# Altium schematic sheet Top.SchDoc(Top)
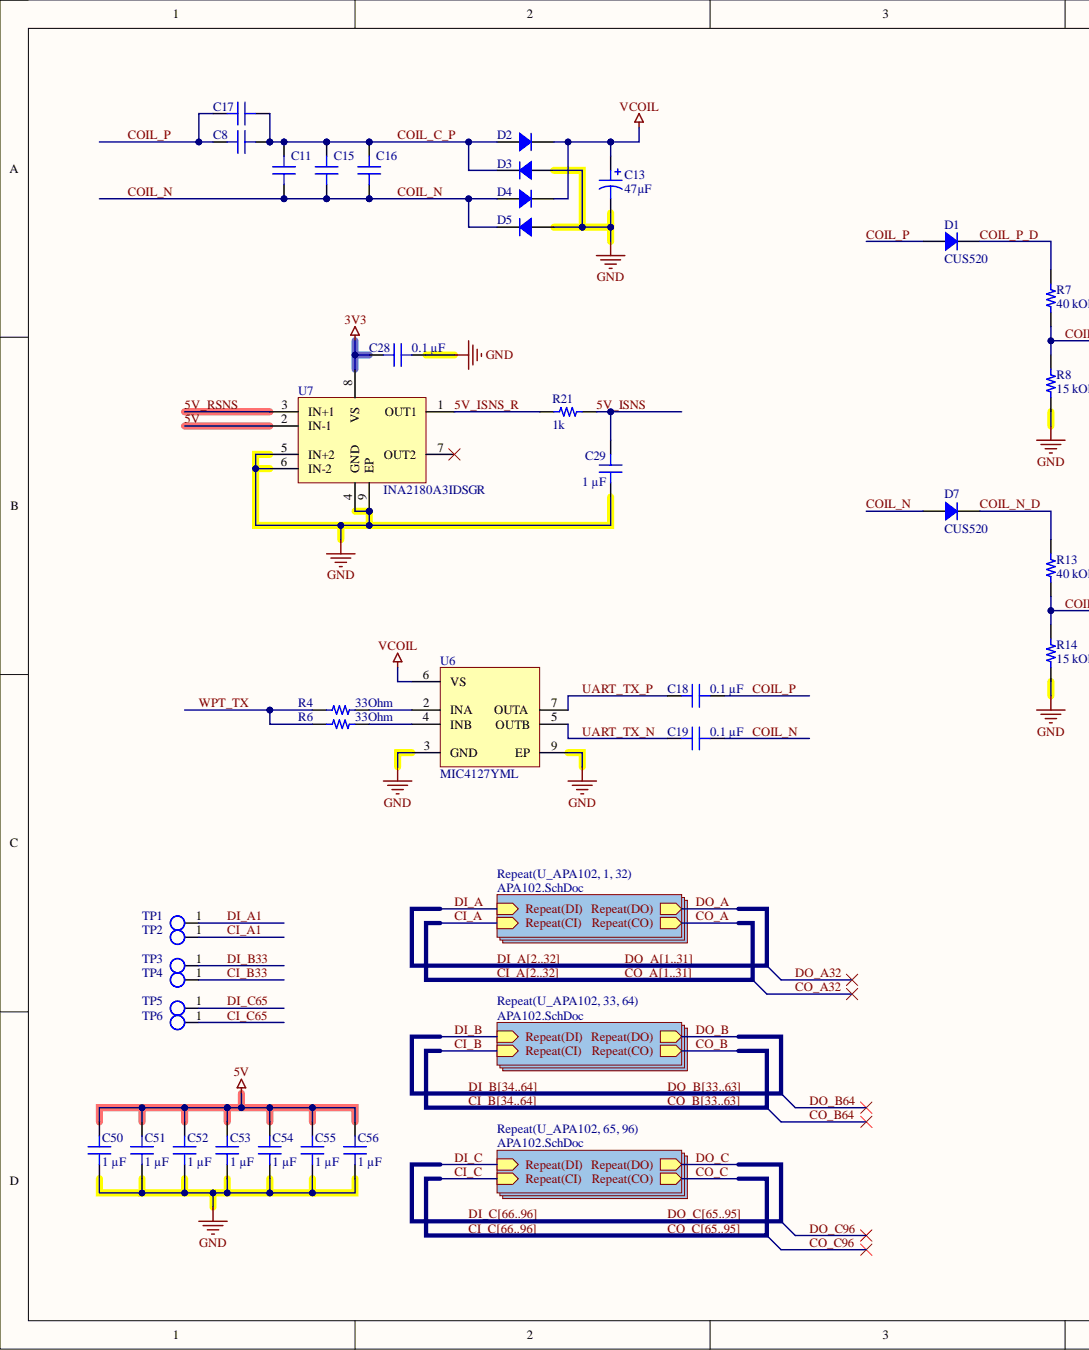
[diagram p0: Top.SchDoc, left]
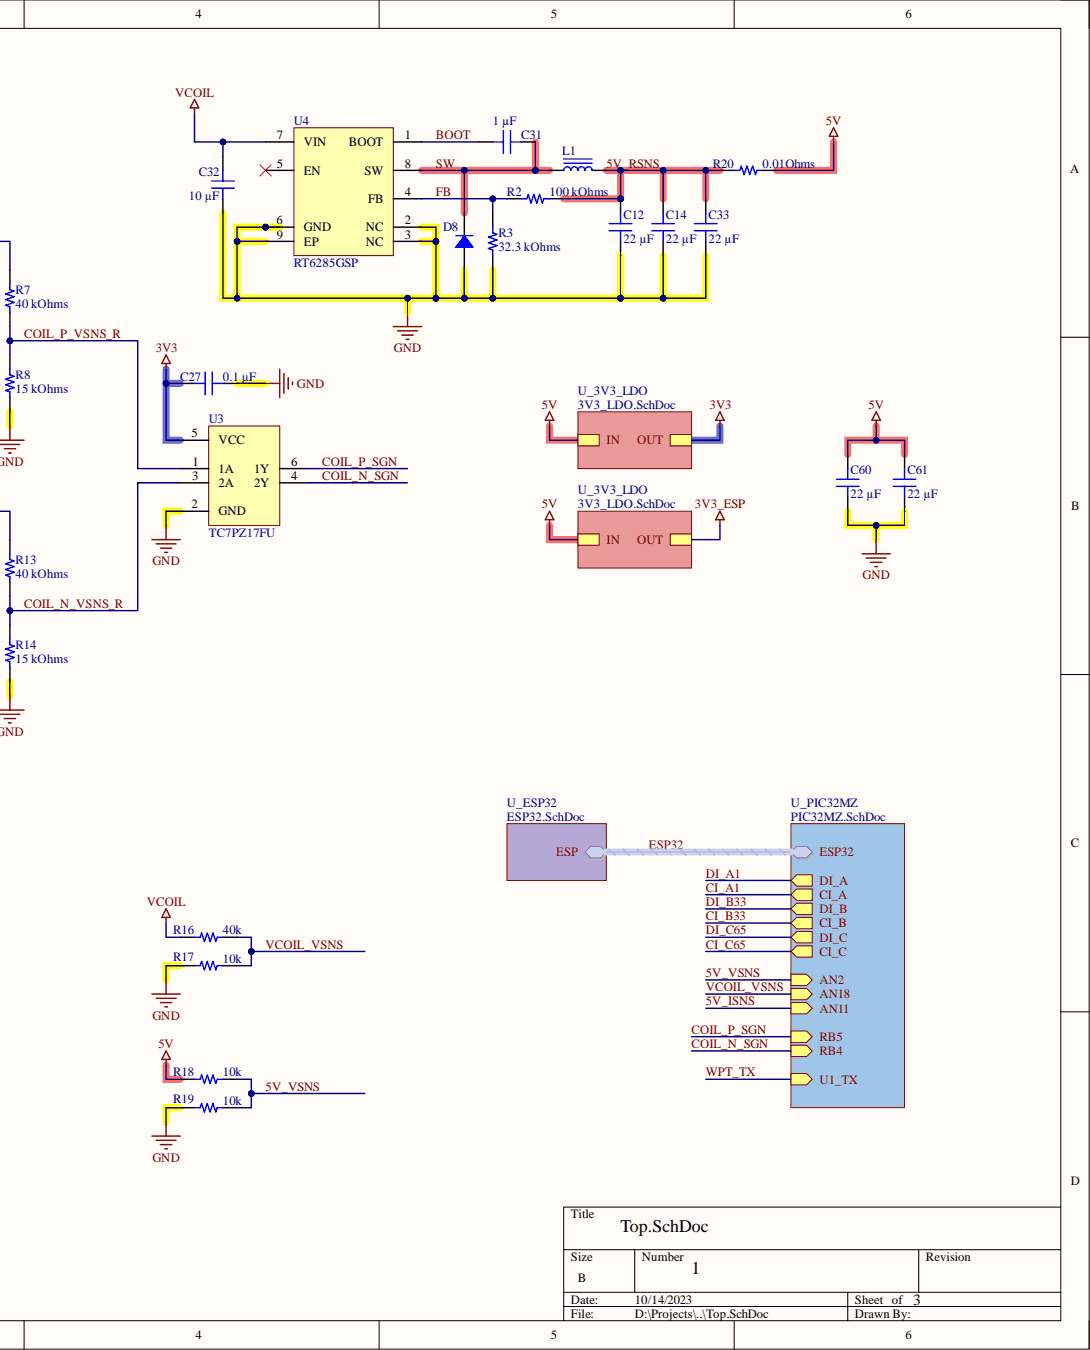
[diagram p1: Top.SchDoc, right]

## Parts
C8  =Value  [CAP 1206_3216 - 0.8MM]
C11  =Value  [CAP 1206_3216 - 0.8MM]
C12  22 µF  [CAP 0603_1608]
C13  47µF  [CAP ALSMD 6.6MM H6.1MM]
C14  22 µF  [CAP 0603_1608]
C15  =Value  [CAP 1206_3216 - 0.8MM]
C16  =Value  [CAP 1206_3216 - 0.8MM]
C17  =Value  [CAP 1206_3216 - 0.8MM]
C18  0.1 µF  [CAP 0402_1005]
C19  0.1 µF  [CAP 0402_1005]
C27  0.1 µF  [CAP 0402_1005]
C28  0.1 µF  [CAP 0402_1005]
C29  1 µF  [CAP 0402_1005]
C31  1 µF  [CAP 0402_1005]
C32  10 µF  [CAP 1210_3225 - 1MM]
C33  22 µF  [CAP 0603_1608]
C50  1 µF  [CAP 0402_1005]
C51  1 µF  [CAP 0402_1005]
C52  1 µF  [CAP 0402_1005]
C53  1 µF  [CAP 0402_1005]
C54  1 µF  [CAP 0402_1005]
C55  1 µF  [CAP 0402_1005]
C56  1 µF  [CAP 0402_1005]
C60  22 µF  [CAP 0603_1608]
C61  22 µF  [CAP 0603_1608]
D1  CUS520  [SOD323]
D2  STPS340UY  [DO214AA SMB]  (value not drawn)
D3  STPS340UY  [DO214AA SMB]  (value not drawn)
D4  STPS340UY  [DO214AA SMB]  (value not drawn)
D5  STPS340UY  [DO214AA SMB]  (value not drawn)
D7  CUS520  [SOD323]
D8  STPS340UY  [DO214AA SMB]  (value not drawn)
L1  MPL-AL5050-5R6  [MPL-AL5050-5R6]  (value not drawn)
R2  100 kOhms  [RES 0402_1005]
R3  32.3 kOhms  [RES 0402_1005]
R4  33Ohm  [RES 0402_1005]
R6  33Ohm  [RES 0402_1005]
R7  40 kOhms  [RES 0402_1005]
R8  15 kOhms  [RES 0402_1005]
R13  40 kOhms  [RES 0402_1005]
R14  15 kOhms  [RES 0402_1005]
R16  40k  [RES 0402_1005]
R17  10k  [RES 0402_1005]
R18  10k  [RES 0402_1005]
R19  10k  [RES 0402_1005]
R20  0.01Ohms  [RES 0805_2012]
R21  1k  [RES 0402_1005]
TP1  0.8mm  [TP  0.8mm]  (value not drawn)
TP2  0.8mm  [TP  0.8mm]  (value not drawn)
TP3  0.8mm  [TP  0.8mm]  (value not drawn)
TP4  0.8mm  [TP  0.8mm]  (value not drawn)
TP5  0.8mm  [TP  0.8mm]  (value not drawn)
TP6  0.8mm  [TP  0.8mm]  (value not drawn)
U3  TC7PZ17FU  [SOT-363]
U4  RT6285GSP  [8-SOIC Richtek]
U6  MIC4127YML  [VDFN-8 3x3x0.9]
U7  INA2180A3IDSGR  [SON50P200X200X80-9N]

## Nets
3V3: C27.2 C28.2 U3.5(VCC) U7.8(VS)
GND: C12.1 C13.2 C14.1 C27.1 C28.1 C29.2 C32.1 C33.1 C50.1 C51.1 C52.1 C53.1 C54.1 C55.1 C56.1 C60.1 C61.1 D3.1 D5.1 D8.1 R3.1 R8.1 R14.1 R17.2 R19.2 U3.2(GND) U4.2(NC) U4.3(NC) U4.6(GND) U4.9(EP) U6.3(GND) U6.9(EP) U7.4(GND) U7.5(IN+2) U7.6(IN-2) U7.9(EP)
VCOIL: C13.1 C32.2 D2.2 D4.2 R16.2 U4.7(VIN) U6.6(VS)
5V: C50.2 C51.2 C52.2 C53.2 C54.2 C55.2 C56.2 C60.2 C61.2 R18.2 R20.2 U7.2(IN-1)
5V_ISNS: C29.1 R21.1
5V_ISNS_R: R21.2 U7.1(OUT1)
5V_RSNS: C12.2 C14.2 C33.2 L1.2 R2.2 R20.1 U7.3(IN+1)
5V_VSNS: R18.1 R19.1
BOOT: C31.2 U4.1(BOOT)
CI_A1: TP2.1
CI_B33: TP4.1
CI_C65: TP6.1
COIL_C_P: C8.1 C11.2 C15.2 C16.2 C17.1 D2.1 D3.2
COIL_N: C11.1 C15.1 C16.1 C19.2 D4.1 D5.2 D7.1
COIL_N_D: D7.2 R13.2
COIL_N_SGN: U3.4(2Y)
COIL_N_VSNS_R: R13.1 R14.2 U3.3(2A)
COIL_P: C8.2 C17.2 C18.2 D1.1
COIL_P_D: D1.2 R7.2
COIL_P_SGN: U3.6(1Y)
COIL_P_VSNS_R: R7.1 R8.2 U3.1(1A)
DI_A1: TP1.1
DI_B33: TP3.1
DI_C65: TP5.1
FB: R2.1 R3.2 U4.4(FB)
SW: C31.1 D8.2 L1.1 U4.8(SW)
UART_TX_N: C19.1 U6.5(OUTB)
UART_TX_P: C18.1 U6.7(OUTA)
VCOIL_VSNS: R16.1 R17.1
WPT_TX: R4.1 R6.1
NetR4_2: R4.2 U6.2(INA)
NetR6_2: R6.2 U6.4(INB)
NetU4_5: U4.5(EN)
NetU7_7: U7.7(OUT2)
3V3_ESP:
CI_A2:  [also labelled CI_A]
CI_A3:  [also labelled CI_A]
CI_A4:  [also labelled CI_A]
CI_A5:  [also labelled CI_A]
CI_A6:  [also labelled CI_A]
CI_A7:  [also labelled CI_A]
CI_A8:  [also labelled CI_A]
CI_A9:  [also labelled CI_A]
CI_A10:  [also labelled CI_A]
CI_A11:  [also labelled CI_A]
CI_A12:  [also labelled CI_A]
CI_A13:  [also labelled CI_A]
CI_A14:  [also labelled CI_A]
CI_A15:  [also labelled CI_A]
CI_A16:  [also labelled CI_A]
CI_A17:  [also labelled CI_A]
CI_A18:  [also labelled CI_A]
CI_A19:  [also labelled CI_A]
CI_A20:  [also labelled CI_A]
CI_A21:  [also labelled CI_A]
CI_A22:  [also labelled CI_A]
CI_A23:  [also labelled CI_A]
CI_A24:  [also labelled CI_A]
CI_A25:  [also labelled CI_A]
CI_A26:  [also labelled CI_A]
CI_A27:  [also labelled CI_A]
CI_A28:  [also labelled CI_A]
CI_A29:  [also labelled CI_A]
CI_A30:  [also labelled CI_A]
CI_A31:  [also labelled CI_A]
CI_A32:  [also labelled CI_A]
CI_B34:  [also labelled CI_B]
CI_B35:  [also labelled CI_B]
CI_B36:  [also labelled CI_B]
CI_B37:  [also labelled CI_B]
CI_B38:  [also labelled CI_B]
CI_B39:  [also labelled CI_B]
CI_B40:  [also labelled CI_B]
CI_B41:  [also labelled CI_B]
CI_B42:  [also labelled CI_B]
CI_B43:  [also labelled CI_B]
CI_B44:  [also labelled CI_B]
CI_B45:  [also labelled CI_B]
CI_B46:  [also labelled CI_B]
CI_B47:  [also labelled CI_B]
CI_B48:  [also labelled CI_B]
CI_B49:  [also labelled CI_B]
CI_B50:  [also labelled CI_B]
CI_B51:  [also labelled CI_B]
CI_B52:  [also labelled CI_B]
CI_B53:  [also labelled CI_B]
CI_B54:  [also labelled CI_B]
CI_B55:  [also labelled CI_B]
CI_B56:  [also labelled CI_B]
CI_B57:  [also labelled CI_B]
CI_B58:  [also labelled CI_B]
CI_B59:  [also labelled CI_B]
CI_B60:  [also labelled CI_B]
CI_B61:  [also labelled CI_B]
CI_B62:  [also labelled CI_B]
CI_B63:  [also labelled CI_B]
CI_B64:  [also labelled CI_B]
CI_C66:  [also labelled CI_C]
CI_C67:  [also labelled CI_C]
CI_C68:  [also labelled CI_C]
CI_C69:  [also labelled CI_C]
CI_C70:  [also labelled CI_C]
CI_C71:  [also labelled CI_C]
CI_C72:  [also labelled CI_C]
CI_C73:  [also labelled CI_C]
CI_C74:  [also labelled CI_C]
CI_C75:  [also labelled CI_C]
CI_C76:  [also labelled CI_C]
CI_C77:  [also labelled CI_C]
CI_C78:  [also labelled CI_C]
CI_C79:  [also labelled CI_C]
CI_C80:  [also labelled CI_C]
CI_C81:  [also labelled CI_C]
CI_C82:  [also labelled CI_C]
CI_C83:  [also labelled CI_C]
CI_C84:  [also labelled CI_C]
CI_C85:  [also labelled CI_C]
CI_C86:  [also labelled CI_C]
CI_C87:  [also labelled CI_C]
CI_C88:  [also labelled CI_C]
CI_C89:  [also labelled CI_C]
CI_C90:  [also labelled CI_C]
CI_C91:  [also labelled CI_C]
CI_C92:  [also labelled CI_C]
CI_C93:  [also labelled CI_C]
CI_C94:  [also labelled CI_C]
CI_C95:  [also labelled CI_C]
CI_C96:  [also labelled CI_C]
CO_A32:
CO_B64:
CO_C96:
DI_A2:  [also labelled DI_A]
DI_A3:  [also labelled DI_A]
DI_A4:  [also labelled DI_A]
DI_A5:  [also labelled DI_A]
DI_A6:  [also labelled DI_A]
DI_A7:  [also labelled DI_A]
DI_A8:  [also labelled DI_A]
DI_A9:  [also labelled DI_A]
DI_A10:  [also labelled DI_A]
DI_A11:  [also labelled DI_A]
DI_A12:  [also labelled DI_A]
DI_A13:  [also labelled DI_A]
DI_A14:  [also labelled DI_A]
DI_A15:  [also labelled DI_A]
DI_A16:  [also labelled DI_A]
DI_A17:  [also labelled DI_A]
DI_A18:  [also labelled DI_A]
DI_A19:  [also labelled DI_A]
DI_A20:  [also labelled DI_A]
DI_A21:  [also labelled DI_A]
DI_A22:  [also labelled DI_A]
DI_A23:  [also labelled DI_A]
DI_A24:  [also labelled DI_A]
DI_A25:  [also labelled DI_A]
DI_A26:  [also labelled DI_A]
DI_A27:  [also labelled DI_A]
DI_A28:  [also labelled DI_A]
DI_A29:  [also labelled DI_A]
DI_A30:  [also labelled DI_A]
DI_A31:  [also labelled DI_A]
DI_A32:  [also labelled DI_A]
DI_B34:  [also labelled DI_B]
DI_B35:  [also labelled DI_B]
DI_B36:  [also labelled DI_B]
DI_B37:  [also labelled DI_B]
DI_B38:  [also labelled DI_B]
DI_B39:  [also labelled DI_B]
DI_B40:  [also labelled DI_B]
DI_B41:  [also labelled DI_B]
DI_B42:  [also labelled DI_B]
DI_B43:  [also labelled DI_B]
DI_B44:  [also labelled DI_B]
DI_B45:  [also labelled DI_B]
DI_B46:  [also labelled DI_B]
DI_B47:  [also labelled DI_B]
DI_B48:  [also labelled DI_B]
DI_B49:  [also labelled DI_B]
DI_B50:  [also labelled DI_B]
DI_B51:  [also labelled DI_B]
DI_B52:  [also labelled DI_B]
DI_B53:  [also labelled DI_B]
DI_B54:  [also labelled DI_B]
DI_B55:  [also labelled DI_B]
DI_B56:  [also labelled DI_B]
DI_B57:  [also labelled DI_B]
DI_B58:  [also labelled DI_B]
DI_B59:  [also labelled DI_B]
DI_B60:  [also labelled DI_B]
DI_B61:  [also labelled DI_B]
DI_B62:  [also labelled DI_B]
DI_B63:  [also labelled DI_B]
DI_B64:  [also labelled DI_B]
DI_C66:  [also labelled DI_C]
DI_C67:  [also labelled DI_C]
DI_C68:  [also labelled DI_C]
DI_C69:  [also labelled DI_C]
DI_C70:  [also labelled DI_C]
DI_C71:  [also labelled DI_C]
DI_C72:  [also labelled DI_C]
DI_C73:  [also labelled DI_C]
DI_C74:  [also labelled DI_C]
DI_C75:  [also labelled DI_C]
DI_C76:  [also labelled DI_C]
DI_C77:  [also labelled DI_C]
DI_C78:  [also labelled DI_C]
DI_C79:  [also labelled DI_C]
DI_C80:  [also labelled DI_C]
DI_C81:  [also labelled DI_C]
DI_C82:  [also labelled DI_C]
DI_C83:  [also labelled DI_C]
DI_C84:  [also labelled DI_C]
DI_C85:  [also labelled DI_C]
DI_C86:  [also labelled DI_C]
DI_C87:  [also labelled DI_C]
DI_C88:  [also labelled DI_C]
DI_C89:  [also labelled DI_C]
DI_C90:  [also labelled DI_C]
DI_C91:  [also labelled DI_C]
DI_C92:  [also labelled DI_C]
DI_C93:  [also labelled DI_C]
DI_C94:  [also labelled DI_C]
DI_C95:  [also labelled DI_C]
DI_C96:  [also labelled DI_C]
DO_A32:
DO_B64:
DO_C96:
ESP32.~{CS}:
ESP32.SCK:
ESP32.SDI:
ESP32.SDO:

## Library data of the parts
C8 C11 C15-C17 = C1206C223KBRAC7800: CAP CER 0.022UF 630V X7R 1206; manufacturer: KEMET; Capacitance: 0.022 µF; Database Table Name: Capacitors - Ceramic - 1206; Tolerance: ±10%; Applications: General Purpose; Component Kind: Standard; componentlink1description: Datasheet; componentlink1url: https://api.kemet.com/component-edge/download/datasheet/C1206C223KBRAC7800.pdf; componentlink2description: DigiKey Link; componentlink2url: https://www.digikey.com/en/products/detail/kemet/C1206C223KBRAC7800/7382212; Device Package: 1206 (3216 Metric); Features: Low ESL, High Voltage; lastupdated: 9/29/2023 7:15:32 PM; Library Name: Celestial Altium Library - altium_library.DbLib; Lifecycle Status: Active; Minimum Order: 1; Mounting Type: Surface Mount, MLCC; Operating Temperature: -55°C ~ 125°C; Package / Case: 1206 (3216 Metric); packaging: TapeAndReel; Part Number: C1206C223KBRAC7800; Part Status: Active; partid: 40769; price: 0.05; series: SMD Comm X7R HV; Size / Dimension: 0.126" L x 0.063" W (3.20mm x 1.60mm); Supplier 1: DigiKey; Supplier Part Number 1: 399-15097-1-ND; Temperature Coefficient: X7R; Thickness (Max): 0.075" (1.90mm); Voltage - Rated: 630V
C12 C14 C33 C60 C61 = 0603ZD226MAT2A: CAP CER 22UF 10V X5R 0603; manufacturer: KYOCERA AVX; Database Table Name: Capacitors - Ceramic - 0603; Tolerance: ±20%; Applications: General Purpose; Capacitance: 22 µF; Component Kind: Standard; componentlink2description: DigiKey Link; componentlink2url: https://www.digikey.com/en/products/detail/kyocera-avx/0603ZD226MAT2A/11268185; Device Package: 0603 (1608 Metric); lastupdated: 9/24/2023 7:08:40 PM; Library Name: Celestial Altium Library - altium_library.DbLib; Lifecycle Status: Active; Minimum Order: 1; Mounting Type: Surface Mount, MLCC; Operating Temperature: -55°C ~ 85°C; Package / Case: 0603 (1608 Metric); packaging: TapeAndReel; Part Number: 0603ZD226MAT2A; Part Status: Active; partid: 35789; price: 0.13; series: -; Size / Dimension: 0.063" L x 0.032" W (1.60mm x 0.81mm); Supplier 1: DigiKey; Supplier Part Number 1: 478-0603ZD226MAT2ACT-ND; Temperature Coefficient: X5R; Thickness (Max): 0.035" (0.90mm); Value: 22 µF; Voltage - Rated: 10V
C13 = EMHL160ARA470MF61G: ALUMINUM CAPACITOR; Manufacturer: United Chemi-Con; Database Table Name: Capacitors - Aluminum - Electrolytic SMT; ESR (Equivalent Series Resistance): 1.2Ohm @ 100kHz; Lifetime @ Temp.: 2000 Hrs @ 125°C; Polarization: Polar; Ripple Current @ High Frequency: 110mA @ 100kHz; Ripple Current @ Low Frequency: 102.3mA @ 120Hz; Surface Mount Land Size: 0.260" L x 0.260" W (6.60mm x 6.60mm); Tolerance: ±20%; Voltage - Rated: 16V; Applications: Automotive; Capacitance: 47µF; Component Kind: Standard; ComponentLink1Description: Datasheet; ComponentLink1URL: http://www.chemi-con.co.jp/cgi-bin/CAT_DB/SEARCH/cat_db_al.cgi?e=e&j=p&pdfname=mhl; ComponentLink2Description: DigiKey Link; ComponentLink2URL: http://digikey.com/product-detail/en/united-chemi-con/EMHL160ARA470MF61G/565-EMHL160ARA470MF61GCT-ND/10487181; Device Package: Radial, Can - SMD; Height - Seated (Max): 0.240" (6.10mm); LastUpdated: 9/2/2020 1:25:27 AM; Library Name: Celestial Altium Library - altium_library.DbLib; Lifecycle Status: Active; Minimum Order: 1; Mounting Type: Surface Mount; Operating Temperature: -40°C ~ 125°C; Package / Case: Radial, Can - SMD; Packaging: TapeAndReel; Part Number: EMHL160ARA470MF61G; Part Status: Active; PartId: 8133; Price: 0.15; Ratings: AEC-Q200; Series: AIchip™- MHL; Size / Dimension: 0.248" Dia (6.30mm); Supplier 1: DigiKey; Supplier Part Number 1: 565-EMHL160ARA470MF61GCT-ND; Value: 47µF
C18 C19 C27 C28 = C1005X5R1E104K050BC: CAP CER 0.1UF 25V X5R 0402; manufacturer: TDK Corporation; Database Table Name: Capacitors - Ceramic - 0402; Tolerance: ±10%; Applications: General Purpose; Capacitance: 0.1 µF; Component Kind: Standard; componentlink1description: Datasheet; componentlink1url: https://product.tdk.com/info/en/catalog/datasheets/mlcc_commercial_general_en.pdf?ref_disty=digikey; componentlink2description: DigiKey Link; componentlink2url: https://www.digikey.com/en/products/detail/tdk-corporation/C1005X5R1E104K050BC/2093232; Device Package: 0402 (1005 Metric); Features: Low ESL; lastupdated: 9/24/2023 7:03:59 PM; Library Name: Celestial Altium Library - altium_library.DbLib; Lifecycle Status: Active; Minimum Order: 1; Mounting Type: Surface Mount, MLCC; Operating Temperature: -55°C ~ 85°C; Package / Case: 0402 (1005 Metric); packaging: TapeAndReel; Part Number: C1005X5R1E104K050BC; Part Status: Active; partid: 34396; price: 0.01; series: C; Size / Dimension: 0.039" L x 0.020" W (1.00mm x 0.50mm); Supplier 1: DigiKey; Supplier Part Number 1: 445-4964-1-ND; Temperature Coefficient: X5R; Thickness (Max): 0.022" (0.55mm); Value: 0.1 µF; Voltage - Rated: 25V
C29 C31 = C1005X5R1E104K050BC: CAP CER 0.1UF 25V X5R 0402; manufacturer: TDK Corporation; Capacitance: 0.1 µF; Database Table Name: Capacitors - Ceramic - 0402; Tolerance: ±10%; Applications: General Purpose; Component Kind: Standard; componentlink1description: Datasheet; componentlink1url: https://product.tdk.com/info/en/catalog/datasheets/mlcc_commercial_general_en.pdf?ref_disty=digikey; componentlink2description: DigiKey Link; componentlink2url: https://www.digikey.com/en/products/detail/tdk-corporation/C1005X5R1E104K050BC/2093232; Device Package: 0402 (1005 Metric); Features: Low ESL; lastupdated: 9/24/2023 7:03:59 PM; Library Name: Celestial Altium Library - altium_library.DbLib; Lifecycle Status: Active; Minimum Order: 1; Mounting Type: Surface Mount, MLCC; Operating Temperature: -55°C ~ 85°C; Package / Case: 0402 (1005 Metric); packaging: TapeAndReel; Part Number: C1005X5R1E104K050BC; Part Status: Active; partid: 34396; price: 0.01; series: C; Size / Dimension: 0.039" L x 0.020" W (1.00mm x 0.50mm); Supplier 1: DigiKey; Supplier Part Number 1: 445-4964-1-ND; Temperature Coefficient: X5R; Thickness (Max): 0.022" (0.55mm); Value: 1 µF; Voltage - Rated: 25V
C32 = C1210X106K050T: CAP CER 10UF 50V X7R 1210; manufacturer: Holy Stone Enterprise Co., Ltd.; Database Table Name: Capacitors - Ceramic - 1210; Tolerance: ±10%; Applications: SMPS Filtering; Capacitance: 10 µF; Component Kind: Standard; componentlink1description: Datasheet; componentlink1url: https://www.holystonecaps.com/PDF/201612051328350.2017%20HCC%20Series.pdf; componentlink2description: DigiKey Link; componentlink2url: https://www.digikey.com/en/products/detail/holy-stone-enterprise-co-ltd/C1210X106K050T/16894866; Device Package: 1210 (3225 Metric); lastupdated: 10/13/2023 7:36:52 PM; Library Name: Celestial Altium Library - altium_library.DbLib; Lifecycle Status: Active; Minimum Order: 1; Mounting Type: Surface Mount, MLCC; Operating Temperature: -55°C ~ 125°C; Package / Case: 1210 (3225 Metric); packaging: TapeAndReel; Part Number: C1210X106K050T; Part Status: Active; partid: 39935; price: 0.23; series: HCC; Size / Dimension: 0.126" L x 0.098" W (3.20mm x 2.50mm); Supplier 1: DigiKey; Supplier Part Number 1: 4587-C1210X106K050TCT-ND; Temperature Coefficient: X7R; Thickness (Max): 0.087" (2.20mm); Value: 10 µF; Voltage - Rated: 50V
C50-C56 = GRM155R61C105MA12D: CAP CER 1UF 16V X5R 0402; manufacturer: Murata Electronics; Database Table Name: Capacitors - Ceramic - 0402; Tolerance: ±20%; Applications: General Purpose; Capacitance: 1 µF; Component Kind: Standard; componentlink1description: Datasheet; componentlink1url: https://search.murata.co.jp/Ceramy/image/img/A01X/G101/ENG/GRM155R61C105MA12-01.pdf; componentlink2description: DigiKey Link; componentlink2url: https://www.digikey.com/en/products/detail/murata-electronics/GRM155R61C105MA12D/4905131; Device Package: 0402 (1005 Metric); lastupdated: 9/24/2023 7:04:06 PM; Library Name: Celestial Altium Library - altium_library.DbLib; Lifecycle Status: Active; Minimum Order: 1; Mounting Type: Surface Mount, MLCC; Operating Temperature: -55°C ~ 85°C; Package / Case: 0402 (1005 Metric); packaging: TapeAndReel; Part Number: GRM155R61C105MA12D; Part Status: Active; partid: 10295; price: 0.01; series: GRM; Size / Dimension: 0.039" L x 0.020" W (1.00mm x 0.50mm); Supplier 1: DigiKey; Supplier Part Number 1: 490-14599-1-ND; Temperature Coefficient: X5R; Thickness (Max): 0.022" (0.55mm); Value: 1 µF; Voltage - Rated: 16V
D1 D7 = NSVRB751V40T1G: DIODE SCHOTTKY 30V 30MA SOD323; Manufacturer: ON Semiconductor; Capacitance @ Vr, F: 2.5pF @ 1V, 1MHz; Current - Average Rectified (Io): 30mA (DC); Current - Reverse Leakage @ Vr: 500nA @ 30V; Database Table Name: Diodes - Rectifiers - Single; Speed: Small Signal =< 200mA (Io), Any Speed; Voltage - DC Reverse (Vr) (Max): 40V; Voltage - Forward (Vf) (Max) @ If: 370mV @ 1mA; Component Kind: Standard; ComponentLink1Description: Datasheet; ComponentLink1URL: https://www.onsemi.com/pub/Collateral/RB751V40T1-D.PDF; ComponentLink2Description: DigiKey Link; ComponentLink2URL: http://digikey.com/product-detail/en/on-semiconductor/NSVRB751V40T1G/NSVRB751V40T1GOSCT-ND/5969287; Diode Type: Schottky; LastUpdated: 9/30/2023 8:39:46 PM; Library Name: Celestial Altium Library - altium_library.DbLib; Lifecycle Status: Active; Minimum Order: 1; Mounting Type: Surface Mount; Operating Temperature - Junction: -55°C ~ 150°C; Package / Case: SC-76, SOD-323; Packaging: TapeAndReel; Part Number: NSVRB751V40T1G; Part Status: Active; PartId: 3084; Price: 0.12; Series: Automotive, AEC-Q101; Supplier 1: DigiKey; Supplier Device Package: SOD-323; Supplier Part Number 1: NSVRB751V40T1GOSCT-ND
D2-D5 D8 = STPS340UY: DIODE SCHOTTKY 40V 3A SMB; Manufacturer: STMicroelectronics; Current - Reverse Leakage @ Vr: 20µA @ 40V; Database Table Name: Diodes - Rectifiers - Single; Speed: Fast Recovery =< 500ns, > 200mA (Io); Voltage - Forward (Vf) (Max) @ If: 630mV @ 3A; Component Kind: Standard; ComponentLink1Description: Datasheet; ComponentLink1URL: http://www.st.com/content/ccc/resource/technical/document/datasheet/9d/f4/fa/9f/2c/39/45/91/CD00270569.pdf/files/CD00270569.pdf/jcr:content/translations/en.CD00270569.pdf; ComponentLink2Description: DigiKey Link; ComponentLink2URL: http://digikey.com/product-detail/en/stmicroelectronics/STPS340UY/497-13432-1-ND/3770079; Current - Average Rectified (Io): 3A; Diode Type: Schottky; LastUpdated: 9/2/2020 1:18:53 AM; Library Name: Celestial Altium Library - altium_library.DbLib; Lifecycle Status: Active; Minimum Order: 1; Mounting Type: Surface Mount; Operating Temperature - Junction: -40°C ~ 150°C; Package / Case: DO-214AA, SMB; Packaging: TapeAndReel; Part Number: STPS340UY; Part Status: Active; PartId: 1402; Price: 0.30; Series: Automotive, AEC-Q101; Supplier 1: DigiKey; Supplier Device Package: SMB; Supplier Part Number 1: 497-13432-1-ND; Voltage - DC Reverse (Vr) (Max): 40V
L1 = MPL-AL5050-5R6: FIXED IND 5.6UH 6.8A 20 MOHM SMD; MF: Laird-Signal Integrity Products; MP: MGV05021R0M-10; Availability: In Stock; Check_prices: https://www.snapeda.com/parts/MGV05021R0M-10/Laird/view-part/5881352/?ref=eda; Component Kind: Standard; Library Name: IC.IntLib; Package: Molded-2 Laird; Price: None; Purchase-URL: https://pricing.snapeda.com/search/part/MGV05021R0M-10/?ref=eda; SnapEDA_Link: https://www.snapeda.com/parts/MGV05021R0M-10/Laird/view-part/5881352/?ref=snap
R2 R4 R6 = ESR01MZPJ224: RES SMD 220K OHM 5% 1/5W 0402; Manufacturer: Rohm Semiconductor; Database Table Name: Resistors - Surface Mount - 0402; Power (Watts): 0.2W, 1/5W; Resistance: 220 kOhms; Temperature Coefficient: ±200ppm/°C; Component Kind: Standard; ComponentLink1Description: Datasheet; ComponentLink1URL: https://www.rohm.com/datasheet/ESR01MZPF/esr-e; ComponentLink2Description: DigiKey Link; ComponentLink2URL: https://www.digikey.com/en/products/detail/rohm-semiconductor/ESR01MZPJ224/4051160; Composition: Thick Film; Device Package: 0402 (1005 Metric); Features: Automotive AEC-Q200, Pulse Withstanding; Height - Seated (Max): 0.016" (0.40mm); LastUpdated: 9/24/2023 7:24:59 PM; Library Name: Celestial Altium Library - altium_library.DbLib; Lifecycle Status: Active; Minimum Order: 1; Number of Terminations: 2; Operating Temperature: -55°C ~ 155°C; Package / Case: 0402 (1005 Metric); Packaging: TapeAndReel; Part Number: ESR01MZPJ224; Part Status: Active; PartId: 14678; Price: 0.03; Ratings: AEC-Q200; Series: ESR; Size / Dimension: 0.039" L x 0.020" W (1.00mm x 0.50mm); Supplier 1: DigiKey; Supplier Device Package: 0402; Supplier Part Number 1: RHM1016CT-ND; Tolerance: ±5%
  R2: Value: 100 kOhms
R3 R7 R8 R13 R14 R16-R19 R21 = RT0402DRE0730KL: RES SMD 30K OHM 0.5% 1/16W 0402; Manufacturer: Yageo; Database Table Name: Resistors - Surface Mount - 0402; Power (Watts): 0.063W, 1/16W; Resistance: 30 kOhms; Temperature Coefficient: ±50ppm/°C; Component Kind: Standard; ComponentLink1Description: Datasheet; ComponentLink1URL: https://www.yageo.com/upload/media/product/productsearch/datasheet/rchip/PYu-RT_1-to-0.01_RoHS_L_11.pdf; ComponentLink2Description: DigiKey Link; ComponentLink2URL: https://www.digikey.com/en/products/detail/yageo/RT0402DRE0730KL/1071471; Composition: Thin Film; Device Package: 0402 (1005 Metric); Height - Seated (Max): 0.014" (0.35mm); LastUpdated: 9/24/2023 7:25:39 PM; Library Name: Celestial Altium Library - altium_library.DbLib; Lifecycle Status: Active; Minimum Order: 1; Number of Terminations: 2; Operating Temperature: -55°C ~ 155°C; Package / Case: 0402 (1005 Metric); Packaging: TapeAndReel; Part Number: RT0402DRE0730KL; Part Status: Active; PartId: 4766; Price: 0.02; Series: RT; Size / Dimension: 0.039" L x 0.020" W (1.00mm x 0.50mm); Supplier 1: DigiKey; Supplier Device Package: 0402; Supplier Part Number 1: 311-2271-1-ND; Tolerance: ±0.5%
  R3: Value: 32.3 kOhms
  R7 R13: Value: 40 kOhms
  R8 R14: Value: 15 kOhms
  R16: Value: 40k
  R17-R19: Value: 10k
  R21: Value: 1k
R20 = ERJ-6CWDR027V: 0805 CURRENT SENSING RES. 0.5%,; Manufacturer: Panasonic Electronic Components; Database Table Name: Resistors - Surface Mount - 0805; Power (Watts): 0.5W, 1/2W; Resistance: 27 mOhms; Temperature Coefficient: ±75ppm/°C; Value: 27 mOhms; Component Kind: Standard; ComponentLink1Description: Datasheet; ComponentLink1URL: https://industrial.panasonic.com/cdbs/www-data/pdf/RDN0000/AOA0000C313.pdf; ComponentLink2Description: DigiKey Link; ComponentLink2URL: https://www.digikey.com/en/products/detail/panasonic-electronic-components/ERJ-6CWDR027V/10261704; Composition: Thick Film; Device Package: 0805 (2012 Metric); Features: Automotive AEC-Q200, Current Sense; Height - Seated (Max): 0.030" (0.75mm); LastUpdated: 10/1/2023 7:53:58 PM; Library Name: Celestial Altium Library - altium_library.DbLib; Lifecycle Status: Active; Minimum Order: 1; Number of Terminations: 2; Operating Temperature: -55°C ~ 125°C; Package / Case: 0805 (2012 Metric); Packaging: TapeAndReel; Part Number: ERJ-6CWDR027V; Part Status: Active; PartId: 40402; Price: 0.13; Ratings: AEC-Q200; Series: ERJ-6CW; Size / Dimension: 0.081" L x 0.051" W (2.05mm x 1.30mm); Supplier 1: DigiKey; Supplier Device Package: 0805; Supplier Part Number 1: P124411CT-ND; Tolerance: ±0.5%
TP1-TP6 = TP 0.8mm: Test point; Component Kind: Standard; Library Name: IC.IntLib
U3 = TC7PZ17FU: 2x Buffer; Component Kind: Standard; Library Name: IC.IntLib
U4 = RT6285GSP: Buck, Adj, 5A; Component Kind: Standard; Library Name: IC.IntLib
U6 = MIC4127YML: Low side Gate Driver 2x; Component Kind: Standard; Library Name: IC.IntLib
U7 = INA2180: Current sense amplifier 2 channel; Component Kind: Standard; Library Name: IC.IntLib
